# Altium schematic sheet mcu_wifi_module.SchDoc
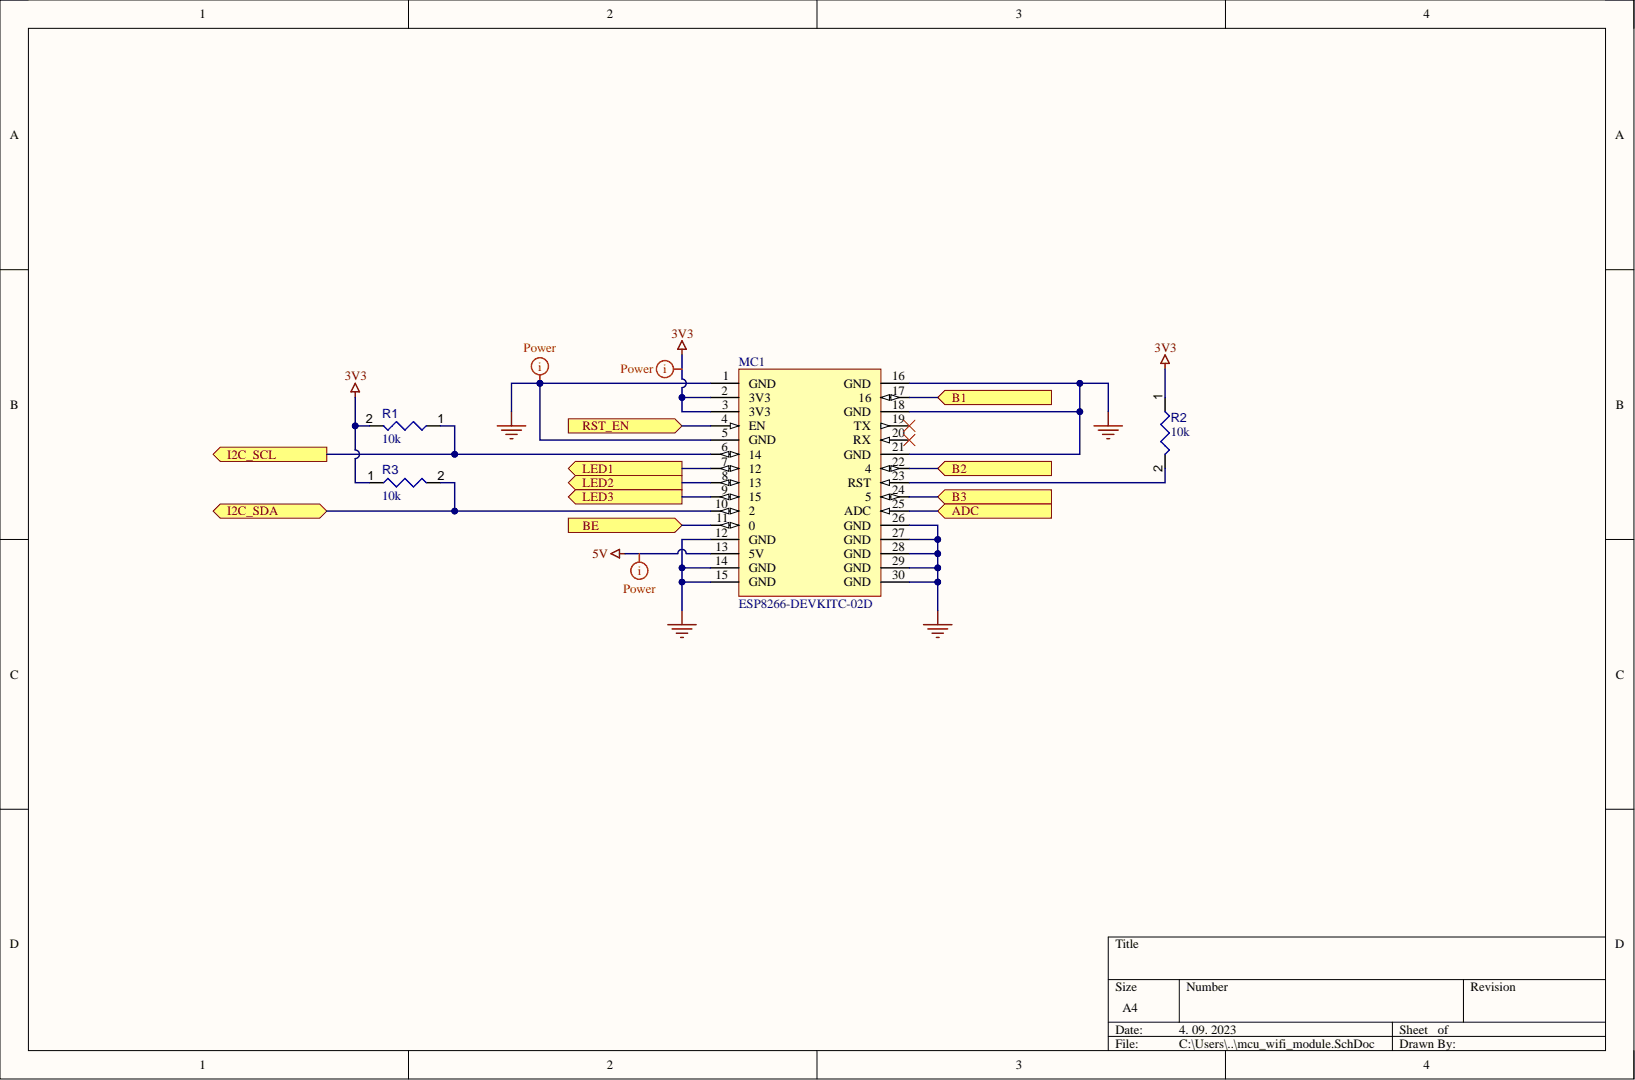
[diagram p0: mcu_wifi_module.SchDoc]

## Parts
MC1  ESP8266-DEVKITC-02D  [ESP8266_DEVKITC]
R1  value 10k  [RESA60-630X240]  (hidden comment: MFR-25FBF52-10K)
R2  value 10k  [RESA60-630X240]  (hidden comment: MFR-25FBF52-10K)
R3  value 10k  [RESA60-630X240]  (hidden comment: MFR-25FBF52-10K)

## Ports
ADC, B1, B2, B3, BE, I2C_SCL, I2C_SDA, LED1, LED2, LED3, RST_EN

## Nets
3V3: MC1.2(3V3) MC1.3(3V3) R1.2 R2.1 R3.1
5V: MC1.13(5V)
GND: MC1.1(GND) MC1.5(GND) MC1.12(GND) MC1.14(GND) MC1.15(GND) MC1.16(GND) MC1.18(GND) MC1.21(GND) MC1.26(GND) MC1.27(GND) MC1.28(GND) MC1.29(GND) MC1.30(GND)
ADC: MC1.25(ADC) ; port ADC
B1: MC1.17 ; port B1
B2: MC1.22 ; port B2
B3: MC1.24 ; port B3
BE: MC1.11 ; port BE
I2C_SCL: MC1.6 R1.1 ; port I2C_SCL
I2C_SDA: MC1.10 R3.2 ; port I2C_SDA
LED1: MC1.7 ; port LED1
LED2: MC1.8 ; port LED2
LED3: MC1.9 ; port LED3
RST_EN: MC1.4(EN) ; port RST_EN
NetMC1_23: MC1.23(RST) R2.2

Not connected: MC1 19(TX) 20(RX)

## Library data of the parts
MC1 = CMP-001-0000-5: ESP-WROOM-02D Eval Board; Component Kind: Standard; Library Name: Budapest University of Technology and Economics
R1-R3 = CMP-009-00047-4: Axial Resistor, 10 KOhm, +/- 1%, 0.25 W, -55 to 155 degC, 2-Pin THD, RoHS, Bulk; Manufacturer: Yageo; Power: 250mW; Voltage Rating: 250V; Case/Package: Axial; Component Kind: Standard; Datasheet URL: http://www.yageo.com/documents/recent/Yageo%20LR_MFR_2013.pdf; Datasheet Version: Apr-13; Manufacturer URL: http://www.yageo.com/; Max Operating Temperature: 155°C; Min Operating Temperature: -55°C; Mounting Technology: TH; Package Description: 2-Pin Axial Lead, Dia 2.4 mm, Body Length 6.3 mm; Package Quantity: 200; Package Reference: MFR-25; Packaging: Bulk; RoHS Compliant: True; Tolerance: 1%; Library Name: Budapest University of Technology and Economics
